# Altium schematic sheet led1.SchDoc
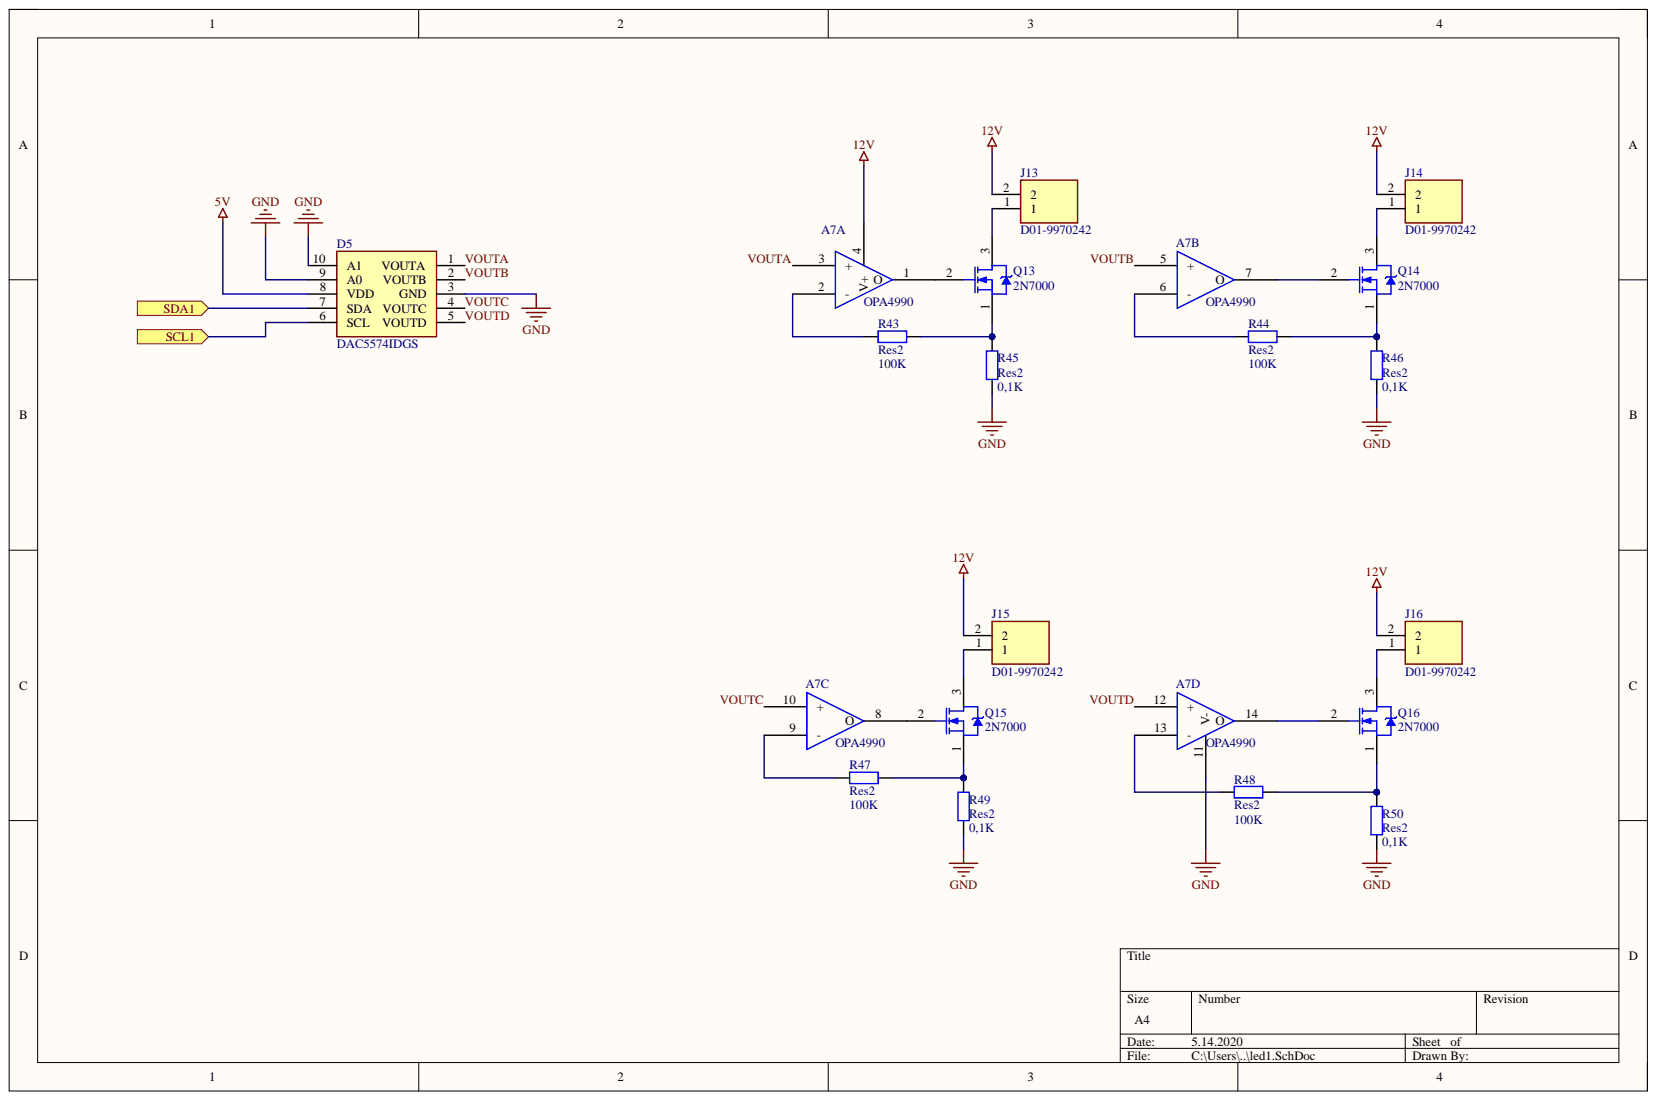
[diagram p0: led1.SchDoc]

## Parts
A7  OPA4990  [SOIC127P600X175-14N]  (parts A,B,C,D)
D5  DAC5574IDGS  [SOP50P490X110-10N]
J13  D01-9970242  [HDR1X2]
J14  D01-9970242  [HDR1X2]
J15  D01-9970242  [HDR1X2]
J16  D01-9970242  [HDR1X2]
Q13  2N7000  [ONSC-TO-92-3-29-11]
Q14  2N7000  [ONSC-TO-92-3-29-11]
Q15  2N7000  [ONSC-TO-92-3-29-11]
Q16  2N7000  [ONSC-TO-92-3-29-11]
R43  Res2  value 100K  [6-0805_L]
R44  Res2  value 100K  [6-0805_L]
R45  Res2  value 0,1K  [C1210]
R46  Res2  value 0,1K  [C1210]
R47  Res2  value 100K  [6-0805_L]
R48  Res2  value 100K  [6-0805_L]
R49  Res2  value 0,1K  [C1210]
R50  Res2  value 0,1K  [C1210]

## Ports
SCL1, SDA1

## Nets
5V: D5.8(VDD)
12V: A7.4(V+) J13.2 J14.2 J15.2 J16.2
GND: A7.11(V-) D5.3(GND) D5.9(A0) D5.10(A1) R45.1 R46.1 R49.2 R50.2
SCL1: D5.6(SCL) ; port SCL1
SDA1: D5.7(SDA) ; port SDA1
VOUTA_1: A7.3(+) D5.1(VOUTA)  [also labelled VOUTA]
VOUTB_1: A7.5(+) D5.2(VOUTB)  [also labelled VOUTB]
VOUTC_1: A7.10(+) D5.4(VOUTC)  [also labelled VOUTC]
VOUTD_1: A7.12(+) D5.5(VOUTD)  [also labelled VOUTD]
NetA7_1: A7.1(O) Q13.2
NetA7_2: A7.2(-) R43.1
NetA7_6: A7.6(-) R44.2
NetA7_7: A7.7(O) Q14.2
NetA7_8: A7.8(O) Q15.2
NetA7_9: A7.9(-) R47.1
NetA7_13: A7.13(-) R48.2
NetA7_14: A7.14(O) Q16.2
NetJ13_1: J13.1 Q13.3
NetJ14_1: J14.1 Q14.3
NetJ15_1: J15.1 Q15.3
NetJ16_1: J16.1 Q16.3
NetQ13_1: Q13.1 R43.2 R45.2
NetQ14_1: Q14.1 R44.1 R46.2
NetQ15_1: Q15.1 R47.2 R49.1
NetQ16_1: Q16.1 R48.1 R50.1

## Library data of the parts
D5 = DAC5574IDGS: Integrated Circuit; Manufacturer_Name: Texas Instruments; Component Kind: Standard; Datasheet Link: http://www.ti.com/lit/gpn/dac5574; Height: 1.1mm; Manufacturer_Part_Number: DAC5574IDGS; Mouser Part Number: 595-DAC5574IDGS; Mouser Price/Stock: https://www.mouser.com/Search/Refine.aspx?Keyword=595-DAC5574IDGS; RS Part Number: 6621533P; RS Price/Stock: http://uk.rs-online.com/web/p/products/6621533P
J13-J16 = D01-9970242: Connector; Manufacturer_Name: HARWIN; Component Kind: Standard; Datasheet Link: https://componentsearchengine.com/Datasheets/1/D01-9970242.pdf; Height: 4.25mm; Library Name: SamacSys2.SchLib; Manufacturer_Part_Number: D01-9970242; Mouser Part Number: 855-D01-9970242; Mouser Price/Stock: https://www.mouser.com/Search/Refine.aspx?Keyword=855-D01-9970242
Q13-Q16 = CMP-1058-00936-1: Small Signal MOSFET, 200 mA, 60 V, N-Channel, 3-Pin TO-92, Bulk Box; Manufacturer: On Semiconductor; Channel Polarity: N Channel; Ciss Typ (pF): 60; Configuration: Single; Coss Typ (pF): 25; Crss Typ (pF): 5; ID Max (A): 0.2; PD Max (W): 0.35; VGS Max (V): 20; VGS(th) Max (V): 3; Component Kind: Standard; ComponentLink1Description: Manufacturer URL; ComponentLink1URL: http://www.onsemi.com/; ComponentLink2Description: Datasheet; ComponentLink2URL: http://www.onsemi.com/pub_link/Collateral/2N7000-D.PDF; ComponentLink3Description: Package Specification; ComponentLink3URL: http://www.onsemi.cn/pub_link/Collateral/2N6426-D.PDF; DatasheetVersion: Rev. 8; Library Name: Components - 24.04.20 - 07.58.SchLib; Mounting Technology: Through Hole; Package Type: TO-92; PackageDescription: 3-Pin Transistor Outline, Body 4.825 x 3.685 mm, Pitch 1.27 mm; PackageReference: TO-92-3-29-11_AM_SL; PackageVersion: Rev. AM; Packing: Bulk Box; PartNumber: 2N7000; V(BR)DSS Min (V): 60
R43-R50 = Res2: Resistor; Component Kind: Standard; LatestRevisionDate: 17-Jul-2002; LatestRevisionNote: Re-released for DXP Platform.; Library Name: Miscellaneous Devices.IntLib; PackageDescription: Axial Device, Thru-Hole; 2 Leads; 0.4 in Pin Spacing; PackageReference: AXIAL-0.4; Published: 8-Jun-2000; Signal Integrity: Res; Simulation: RESISTOR; Publisher: Altium Limited
A7 = OPA4990: Component Kind: Standard; Library Name: Kalibracija.SchLib
